# Altium schematic sheet Power.SchDoc
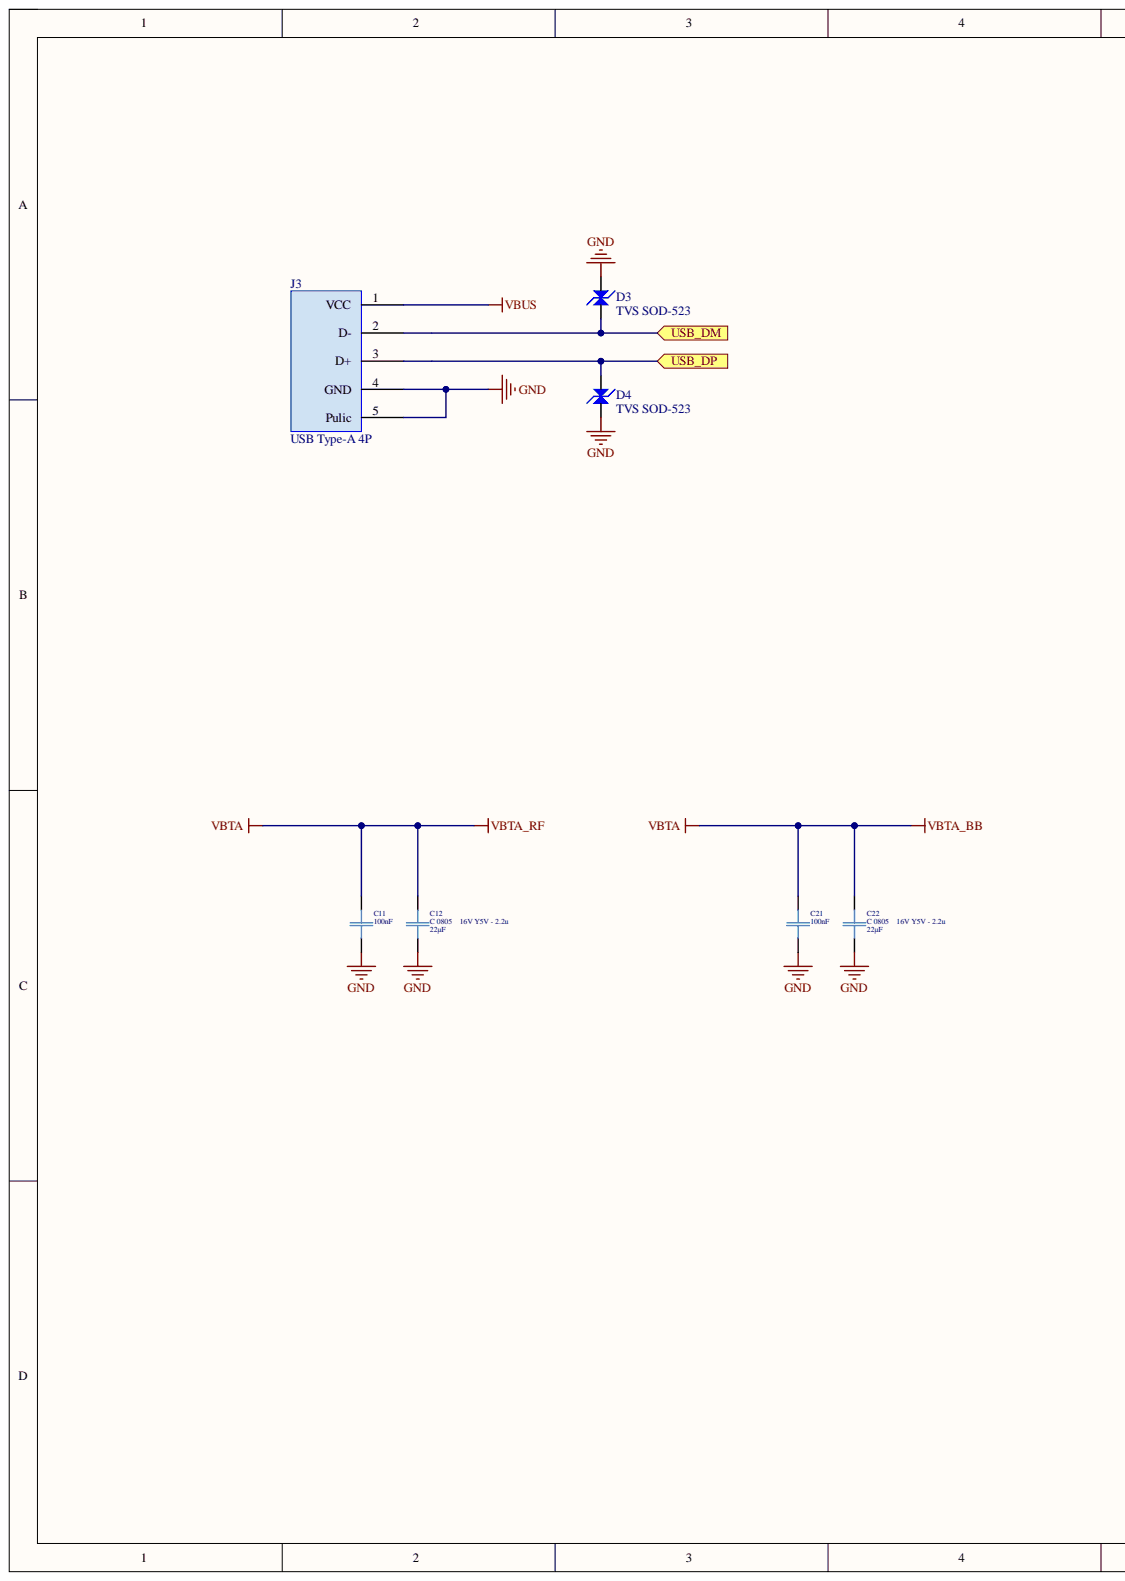
[diagram p0: Power.SchDoc, left]
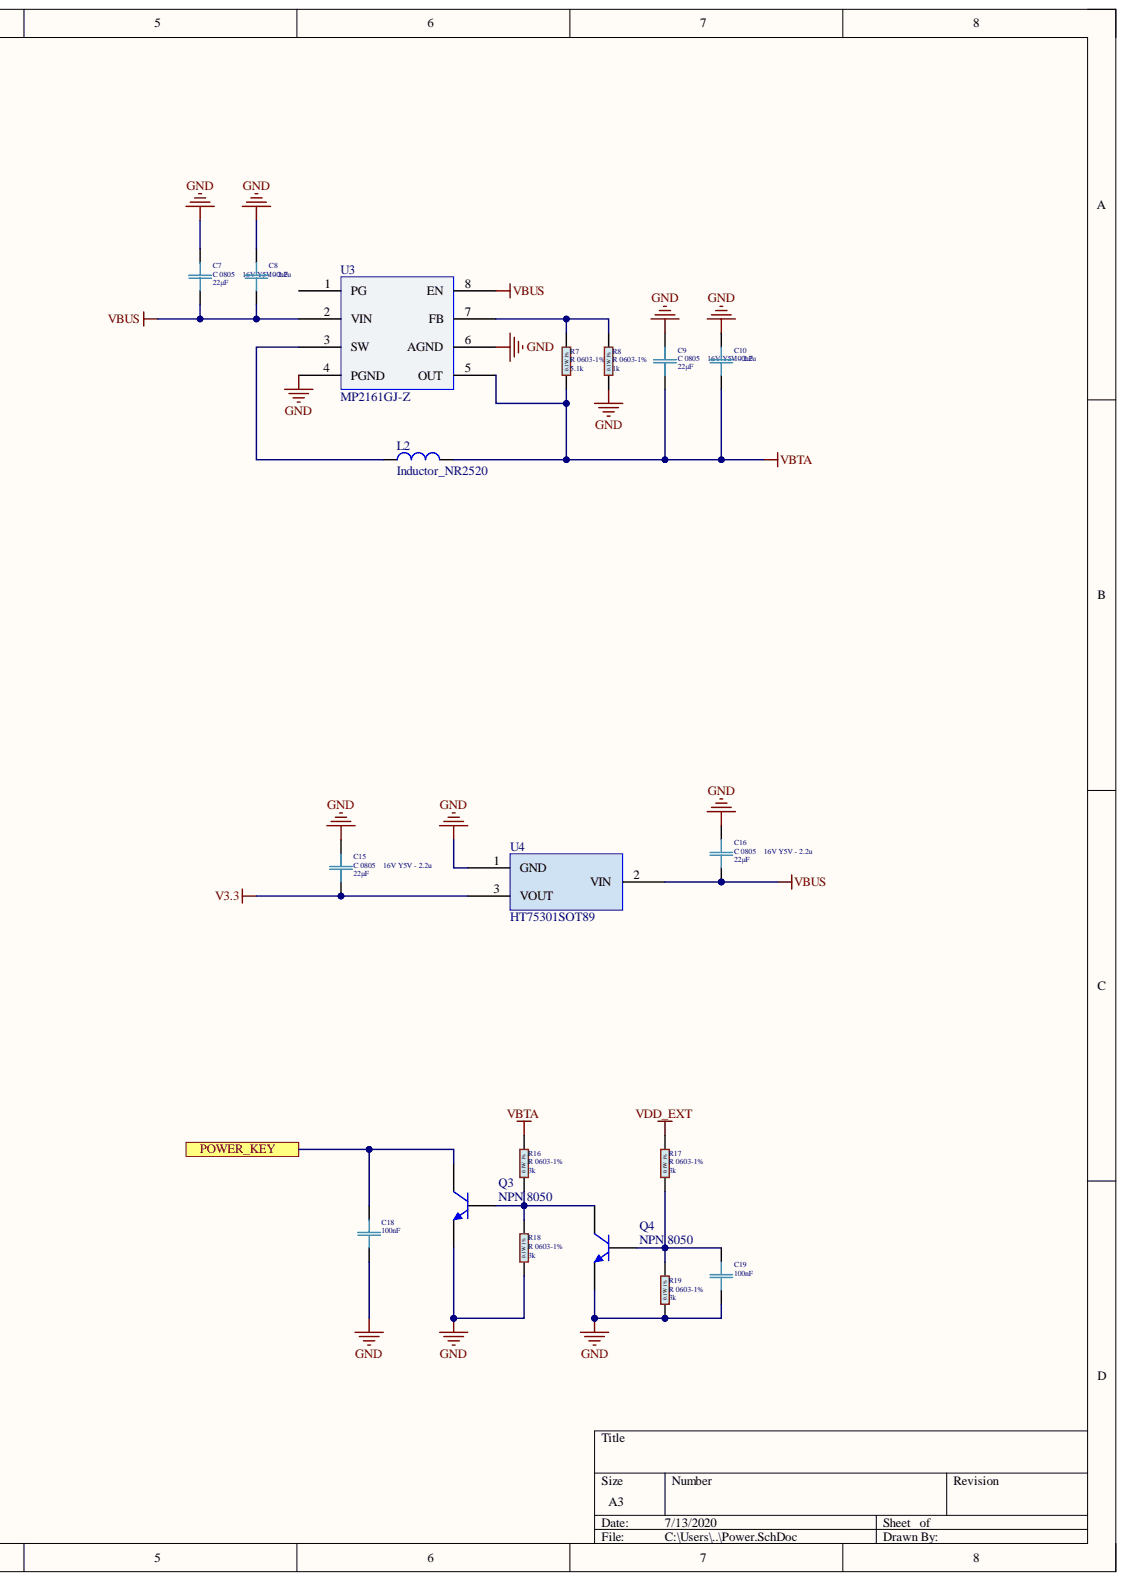
[diagram p1: Power.SchDoc, right]

## Parts
C7  C 0805    16V Y5V - 2.2u  value 22µF  Case/Package: 0805  [C 0805(2012)x1.25]
C8  value 100nF  [C 0603(1608)x0.8]  (hidden comment: C 0603    50V X7R - 0*)
C9  C 0805    16V Y5V - 2.2u  value 22µF  Case/Package: 0805  [C 0805(2012)x1.25]
C10  value 100nF  [C 0603(1608)x0.8]  (hidden comment: C 0603    50V X7R - 0*)
C11  value 100nF  [C 0603(1608)x0.8]  (hidden comment: C 0603    50V X7R - 0*)
C12  C 0805    16V Y5V - 2.2u  value 22µF  Case/Package: 0805  VDC: 16V  [C 0805(2012)x1.25]
C15  C 0805    16V Y5V - 2.2u  value 22µF  Case/Package: 0805  VDC: 16V  [C 0805(2012)x1.25]
C16  C 0805    16V Y5V - 2.2u  value 22µF  Case/Package: 0805  VDC: 16V  [C 0805(2012)x1.25]
C18  value 100nF  [C 0603(1608)x0.8]  (hidden comment: C 0603    50V X7R - 0*)
C19  value 100nF  [C 0603(1608)x0.8]  (hidden comment: C 0603    50V X7R - 0*)
C21  value 100nF  [C 0603(1608)x0.8]  (hidden comment: C 0603    50V X7R - 0*)
C22  C 0805    16V Y5V - 2.2u  value 22µF  Case/Package: 0805  VDC: 16V  [C 0805(2012)x1.25]
D3  TVS SOD-523  [TVS SOD-523-Footprint-1]
D4  TVS SOD-523  [TVS SOD-523-Footprint-1]
J3  USB Type-A 4P  [USB Type-A 4P-Footprint-1]
L2  Inductor_NR2520  [Inductor_NR2520-Footprint-1]
Q3  NPN 8050  [NPN 8050-Footprint-1]
Q4  NPN 8050  [NPN 8050-Footprint-1]
R7  R 0603-1%  value 5.1k  Tolerance: 1%  [R 0603(1608) -1%]
R8  R 0603-1%  value 1k  Tolerance: 1%  [R 0603(1608) -1%]
R16  R 0603-1%  value 3k  Tolerance: 1%  [R 0603(1608) -1%]
R17  R 0603-1%  value 3k  Tolerance: 1%  [R 0603(1608) -1%]
R18  R 0603-1%  value 3k  Tolerance: 1%  [R 0603(1608) -1%]
R19  R 0603-1%  value 3k  Tolerance: 1%  [R 0603(1608) -1%]
U3  MP2161GJ-Z  [MP2161GJ-Z-Footprint-1]
U4  HT75301SOT89  [HT75301SOT89-Footprint-1]

## Ports
POWER_KEY, USB_DM, USB_DP

## Nets
GND: C7.1 C8.2 C9.1 C10.2 C11.2 C12.1 C15.1 C16.2 C18.1 C19.1 C21.2 C22.1 D3.1 D4.2 J3.4(GND) J3.5(Pulic) Q3.2 Q4.2 R8.1 R18.1 R19.1 U3.4(PGND) U3.6(AGND) U4.1
V3.3: C15.2 U4.3
VBTA: C9.2 C10.1 C11.1 C12.2 C21.1 C22.2 L2.2 R7.1 R16.2 U3.5(OUT)
VBUS: C7.2 C8.1 C16.1 J3.1(VCC) U3.2(VIN) U3.8(EN) U4.2
VDD_EXT: R17.2
POWER_KEY: C18.2 Q3.3 ; port POWER_KEY
USB_DM: D3.2 J3.2(D-) ; port USB_DM
USB_DP: D4.1 J3.3(D+) ; port USB_DP
NetC19_2: C19.2 Q4.1 R17.1 R19.2
NetL2_1: L2.1 U3.3(SW)
NetQ3_1: Q3.1 Q4.3 R16.1 R18.2
NetR7_2: R7.2 R8.2 U3.7(FB)

Not connected: U3 1(PG)

## Library data of the parts
C7 C9 C12 C15 C16 C22 = CMP-023-0054-1: SMD 0805     16V Y5V -82/+22%; Manufacturer: Samsung; Part Number: CL21F225ZOFNNNG; Package-mm: 2012; Tolerance: 22%; Component Kind: Standard; ComponentLink1Description: Datasheet Link; ComponentLink1URL: https://store.comet.bg/download-file.php?id=11; Design Item ID: C 0805    16V Y5V - 2.2u; Library Name: <Company> Vault; Mount: SMT; Mount Type: SMT; Price: 0.064; Published: 07.04.2019; RoHS: Y; Signal Integrity: Capacitor; Simulation: Capacitor; Source: SMD Passive.SchLib; Technology: Ceramic  MLCC; TempCo: Y5V; Temperature Range: -30°C ~ +85°C; Publisher: Hefest
  C7 C9: VDC: 16V
C8 C10 C11 C18 C19 C21 = CMP-023-0039-1: SMD 0603     50V X7R 10%; Manufacturer: Samsung; Package-mm: 1608; Case/Package: 0603; Component Kind: Standard; ComponentLink1Description: Datasheet Link; ComponentLink1URL: https://store.comet.bg/download-file.php?id=11; Design Item ID: C 0603    50V X7R - 0*; Library Name: <Company> Vault; Mount: SMT; Mount Type: SMT; Published: 07.04.2019; RoHS: Y; Signal Integrity: Capacitor; Simulation: Capacitor; Source: SMD Passive.SchLib; Technology: Ceramic  MLCC; TempCo: X7R; Temperature Range: -55°C ~ +125°C; Tolerance: 10%; VDC: 50V; Publisher: Hefest
D3 D4 = CMP-00017-1: TVS二极管; breakdown voltage: 6V; reverse voltage: 5V; Component Kind: Standard; Library Name: <Company> Vault
J3 = CMP-00140-1: USB公头; Component Kind: Standard; Library Name: <Company> Vault
L2 = CMP-00012-1: 电源电路用电感; accuracy: ±20%; Inductance: 2.2uH; Case/Package: 2520; Component Kind: Standard; Library Name: <Company> Vault; Mount: SMD; Pins: 2P; RoHS: Yes
Q3 Q4 = CMP-00009-2: NPN Bipolar Transistor; Component Kind: Standard; Library Name: <Company> Vault
R7 R8 R16-R19 = CMP-025-0004-1: SMD 0603 0.1W     1%   75V; Manufacturer: YAGEO; Package-mm: 1608; Power: 100mW; TempCo: ±100ppm/°C; Value Code: 1001; Case/Package: 0603; Component Kind: Standard; ComponentLink1Description: Datasheet Link; ComponentLink1URL: https://store.comet.bg/download-file.php?id=1224; Design Item ID: R 0603-1%; Library Name: <Company> Vault; Mount: SMT; Mount Type: SMT; Price: 0.017; Published: 07.04.2019; RoHS: Y; Signal Integrity: Resistor; Simulation: Resistor; Source: SMD Passive.SchLib; Technology: Thick film; Temperature Range: -55°C ~ +155°C; VDC: 75V; Publisher: Hefest
U3 = CMP-00070-1: Switching Voltage Regulators 2A, 6V, 1.5MHz COT Sync Step Dwn Swtchr; Min Input Voltage: 2.5V; Min Output Voltage: 600mV; Number of Outputs: 1; Output Configuration: Positive; Output Type: Adjustable; Synchronous Rectifier: Yes; Case/Package: SOT-23-8; Component Kind: Standard; Lead Free: Lead Free; Library Name: <Company> Vault; Max Input Voltage: 6V; Max Operating Temperature: 125°C; Max Output Voltage: 6V; Min Operating Temperature: -40°C; Mount: Surface Mount; Output Current: 2A; Package Quantity: 3000; Packaging: Tape and Reel; Switching Frequency: 1.5MHz
U4 = CMP-00134-1: Holtek HT7530-1-SOT89, LDO Voltage Regulator, 100mA, 3 V 3%, Maximum of 30 Vin, 3-Pin SOT-89; Max Input Voltage: 30V; Number of Outputs: 1; Output Type: Fixed; Output Voltage: 3V; Quiescent Current: 4uA; Accuracy: 3%; Case/Package: SOT; Component Kind: Standard; Height: 1.6mm; Length: 4.6mm; Library Name: <Company> Vault; Max Operating Temperature: 85°C; Max Output Current: 100mA; Min Operating Temperature: -40°C; Mount: Surface Mount; Number of Pins: 3; Width: 2.6mm
